# Altium schematic sheet STM32F401RCT6最小系统板.SchDoc
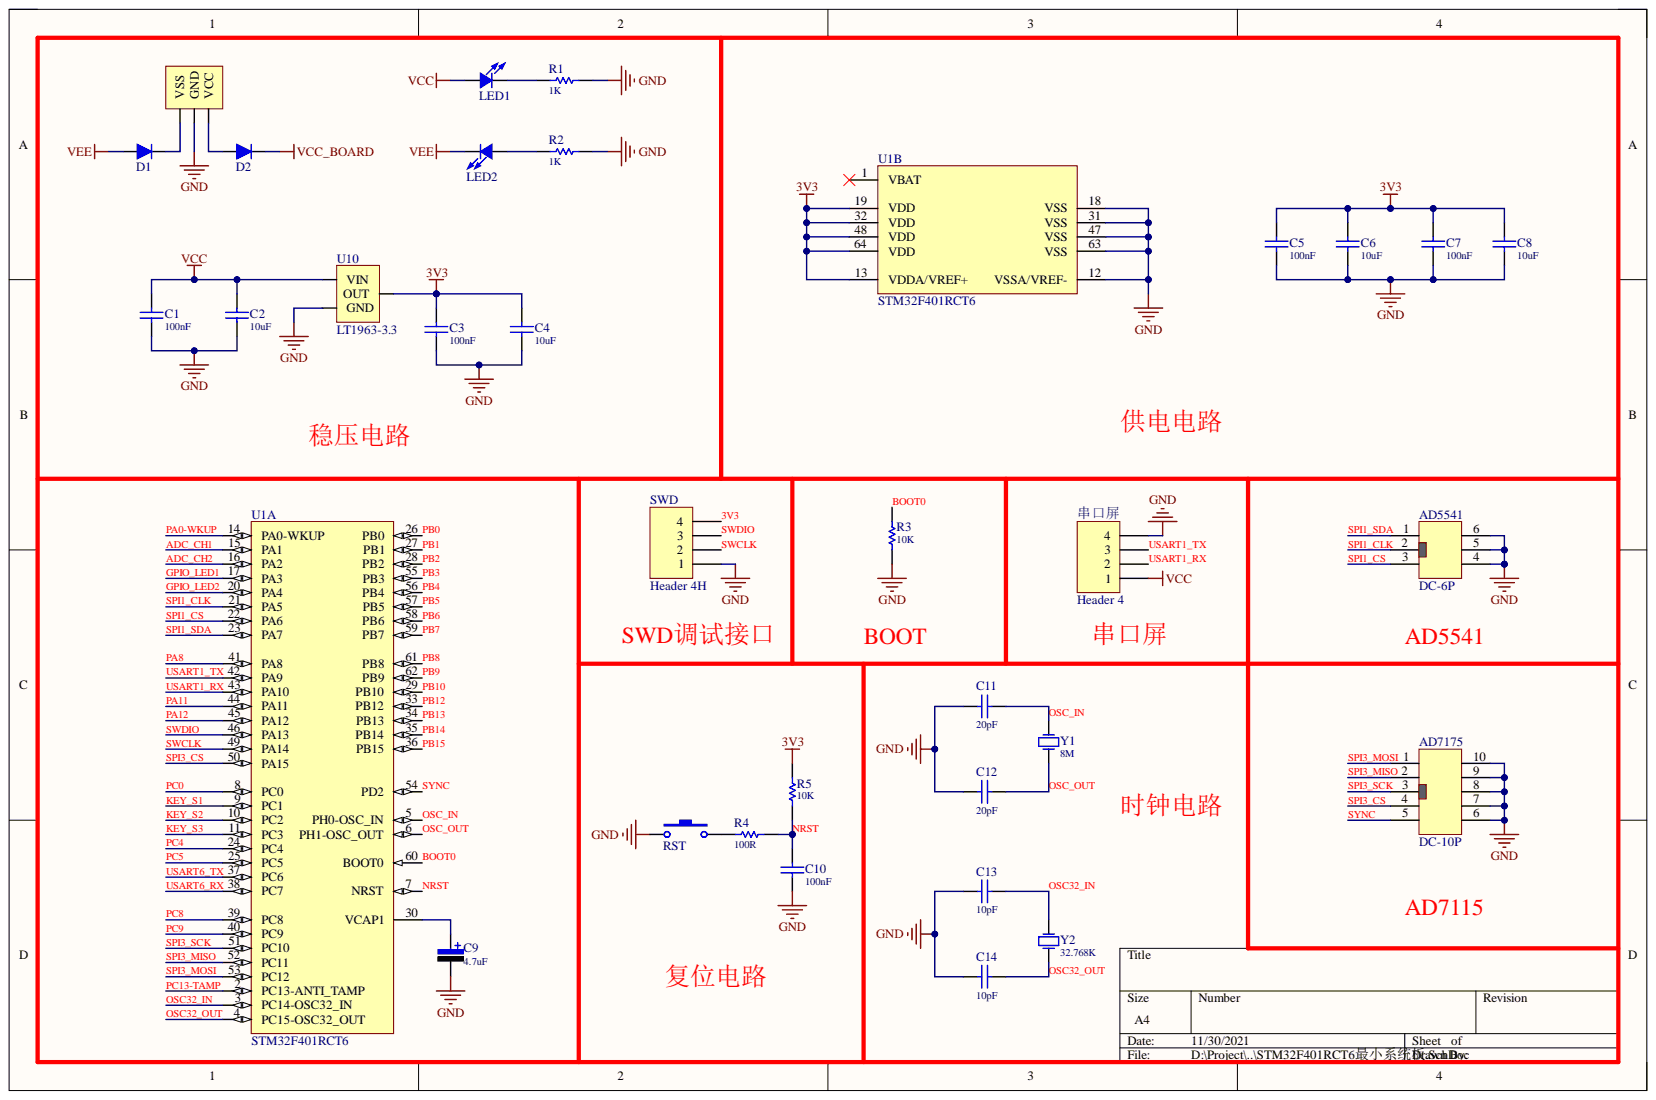
[diagram p0: STM32F401RCT6最小系统板.SchDoc]

## Parts
AD5541  DC-6P  [DC-6P]
AD7175  DC-10P  [DC-10P]
C1  value 100nF  [C0603-L]  (hidden comment: Cap-S)
C2  value 10uF  [C0603-L]  (hidden comment: Cap-S)
C3  value 100nF  [C0603-L]  (hidden comment: Cap-S)
C4  value 10uF  [C0603-L]  (hidden comment: Cap-S)
C5  value 100nF  [C0603-L]  (hidden comment: Cap-S)
C6  value 10uF  [C0603-L]  (hidden comment: Cap-S)
C7  value 100nF  [C0603-L]  (hidden comment: Cap-S)
C8  value 10uF  [C0603-L]  (hidden comment: Cap-S)
C9  C  value 4.7uF  [C-RB-SD4]
C10  value 100nF  [C0603-L]  (hidden comment: Cap-S)
C11  value 20pF  [C0603-L]  (hidden comment: Cap-S)
C12  value 20pF  [C0603-L]  (hidden comment: Cap-S)
C13  value 10pF  [C0603-L]  (hidden comment: Cap-S)
C14  value 10pF  [C0603-L]  (hidden comment: Cap-S)
D1  D  [D-SMA]
D2  D  [D-SMA]
J1  Power-3P  [SIPA03]  (value not drawn)
LED1  LED  [Led-0805_L]
LED2  LED  [Led-0805_L]
R1  R  value 1K  [R0603-L]
R2  R  value 1K  [R0603-L]
R3  R  value 10K  [R0603-L]
R4  R  value 100R  [R0603-L]
R5  R  value 10K  [R0603-L]
RST  SW-PB2  [SW-PB2-SMD]  (value not drawn)
SWD  Header 4H  [HDR1X4H]
U1  STM32F401RCT6  [STM-LQFP64_N]  (parts A,B)
U10  LT1963-3.3  [SOT-223]
Y1  value 8M  [XTAL-PB2-DIP]  (hidden comment: XTAL)
Y2  value 32.768K  [XTAL-32768]  (hidden comment: XTAL)
串口屏  Header 4  [XH2.54-WI-4P]

## Nets
GND: AD5541.4 AD5541.5 AD5541.6 AD7175.6 AD7175.7 AD7175.8 AD7175.9 AD7175.10 C1.1 C2.1 C3.1 C4.1 C5.1 C6.1 C7.1 C8.1 C9.2 C10.1 C11.1 C12.1 C13.1 C14.1 J1.2 R1.2 R2.2 R3.1 RST.1 SWD.1 U1.12(VSSA/VREF-) U1.18(VSS) U1.31(VSS) U1.47(VSS) U1.63(VSS) U10.1 串口屏.4
VCC: C1.2 C2.2 LED1.1 U10.3 串口屏.1
VCC_BOARD: D2.2
VEE: D1.1 LED2.2
3V3: C3.2 C4.2 C5.2 C6.2 C7.2 C8.2 R5.2 SWD.4 U1.13(VDDA/VREF+) U1.19(VDD) U1.32(VDD) U1.48(VDD) U1.64(VDD) U10.2
ADC_CH1: U1.15(PA1)
ADC_CH2: U1.16(PA2)
BOOT0: R3.2 U1.60(BOOT0)
GPIO_LED1: U1.17(PA3)
GPIO_LED2: U1.20(PA4)
KEY_S1: U1.9(PC1)
KEY_S2: U1.10(PC2)
KEY_S3: U1.11(PC3)
NRST: C10.2 R4.2 R5.1 U1.7(NRST)
OSC32_IN: C13.2 U1.3(PC14-OSC32_IN) Y2.2
OSC32_OUT: C14.2 U1.4(PC15-OSC32_OUT) Y2.1
OSC_IN: C11.2 U1.5(PH0-OSC_IN) Y1.2
OSC_OUT: C12.2 U1.6(PH1-OSC_OUT) Y1.1
PA0-WKUP: U1.14(PA0-WKUP)
PA8: U1.41(PA8)
PA11: U1.44(PA11)
PA12: U1.45(PA12)
PB0: U1.26(PB0)
PB1: U1.27(PB1)
PB2: U1.28(PB2)
PB3: U1.55(PB3)
PB4: U1.56(PB4)
PB5: U1.57(PB5)
PB6: U1.58(PB6)
PB7: U1.59(PB7)
PB8: U1.61(PB8)
PB9: U1.62(PB9)
PB10: U1.29(PB10)
PB12: U1.33(PB12)
PB13: U1.34(PB13)
PB14: U1.35(PB14)
PB15: U1.36(PB15)
PC0: U1.8(PC0)
PC4: U1.24(PC4)
PC5: U1.25(PC5)
PC8: U1.39(PC8)
PC9: U1.40(PC9)
PC13-TAMP: U1.2(PC13-ANTI_TAMP)
SPI1_CLK: AD5541.2 U1.21(PA5)
SPI1_CS: AD5541.3 U1.22(PA6)
SPI1_SDA: AD5541.1 U1.23(PA7)
SPI3_CS: AD7175.4 U1.50(PA15)
SPI3_MISO: AD7175.2 U1.52(PC11)
SPI3_MOSI: AD7175.1 U1.53(PC12)
SPI3_SCK: AD7175.3 U1.51(PC10)
SWCLK: SWD.2 U1.49(PA14)
SWDIO: SWD.3 U1.46(PA13)
SYNC: AD7175.5 U1.54(PD2)
USART1_RX: U1.43(PA10) 串口屏.2
USART1_TX: U1.42(PA9) 串口屏.3
USART6_RX: U1.38(PC7)
USART6_TX: U1.37(PC6)
NetC9_1: C9.1 U1.30(VCAP1)
NetD1_2: D1.2 J1.3
NetD2_1: D2.1 J1.1
NetLED1_2: LED1.2 R1.1
NetLED2_1: LED2.1 R2.1
NetR4_1: R4.1 RST.2

Not connected: U1 1(VBAT)

## Library data of the parts
C1-C8 C10-C14 = Cap-S: 贴片瓷片电容; Component Kind: Standard; Library Name: XuZhe.IntLib
C9 = Cap-Pol-ES: 极性贴片电解电容; Component Kind: Standard; Library Name: XuZhe.IntLib
D1 D2 = Diode: 二极管; Component Kind: Standard; Library Name: XuZhe.IntLib
J1 = Power-3P: 供电端子; Component Kind: Standard; Library Name: XuZhe.IntLib
LED1 LED2 = LED: 发光二极管; Component Kind: Standard; Library Name: XuZhe.IntLib
R1-R5 = Res: 贴片电阻; Component Kind: Standard; Library Name: XuZhe.IntLib
RST = SW-PB2: 贴片式2脚微动开关; Component Kind: Standard; Library Name: XuZhe.IntLib
SWD = Header 4H: Header, 4-Pin, Right Angle; Component Kind: Standard; LatestRevisionDate: 17-Jul-2002; LatestRevisionNote: Re-released for DXP Platform.; Library Name: Miscellaneous Connectors.IntLib; Publisher: Altium Limited
U1 = STM32F401RCT6: ARM Cortex-M4 32-bit MCU+FPU, 105 DMIPS, 256 kB Flash, 64 kB Internal RAM, 48 I/Os, 64-pin LQFP, -40 to 85 degC, Tray; Manufacturer: STMicroelectronics; FLASH Size (Prog) (kB): 256; I/Os (High Current): 48; Internal RAM Size (kB): 64; Operating Frequency(F) (Processor speed) (MHz): 84; Supply Voltage(Vcc) max (V): 3.6; Supply Voltage(Vcc) min (V): 1.8; Component Kind: Standard; ComponentLink1Description: Manufacturer URL; ComponentLink1URL: http://www.st.com/web/en/home.html; ComponentLink2Description: Datasheet; ComponentLink2URL: http://www.st.com/st-web-ui/static/active/en/resource/technical/document/data_brief/DM00071938.pdf; ComponentLink3Description: Package Specification; ComponentLink3URL: http://www.st.com/st-web-ui/static/active/en/resource/technical/document/datasheet/DM00037051.pdf; Core: ARM Cortex-M4; DatasheetVersion: Rev. 1; Library Name: STMicroelectronics STM32 F4.IntLib; Mounting Technology: Surface Mount; PackageDescription: 64-Pin Low Profile Quad Flat Package, 10 x 10 mm Body, 0.5 mm Pitch; PackageReference: LQFP64; PackageVersion: Rev. 4, 06/2013; Packing: Tray; PartNumber: STM32F401RCT6; Supplier 1: Digi-Key; Supplier 2: Newark; Supplier 3: Mouser; Supplier Part Number 1: 497-14047-ND; Supplier Part Number 2: 19X6221; Supplier Part Number 3: 511-STM32F401RCT6
U10 = AMS1117: Component Kind: Standard; Library Name: XuZhe.IntLib
Y1 Y2 = XTAL: 晶振; Component Kind: Standard; Library Name: XuZhe.IntLib
串口屏 = Header 4: Header, 4-Pin; Component Kind: Standard; LatestRevisionDate: 17-Jul-2002; LatestRevisionNote: Re-released for DXP Platform.; Library Name: Miscellaneous Connectors.IntLib; Publisher: Altium Limited
AD5541 = DC-6P: Component Kind: Standard; Library Name: XuZhe.IntLib
AD7175 = DC-10P: Component Kind: Standard; Library Name: XuZhe.IntLib
